# Altium schematic sheet IndicatorBox.SchDoc
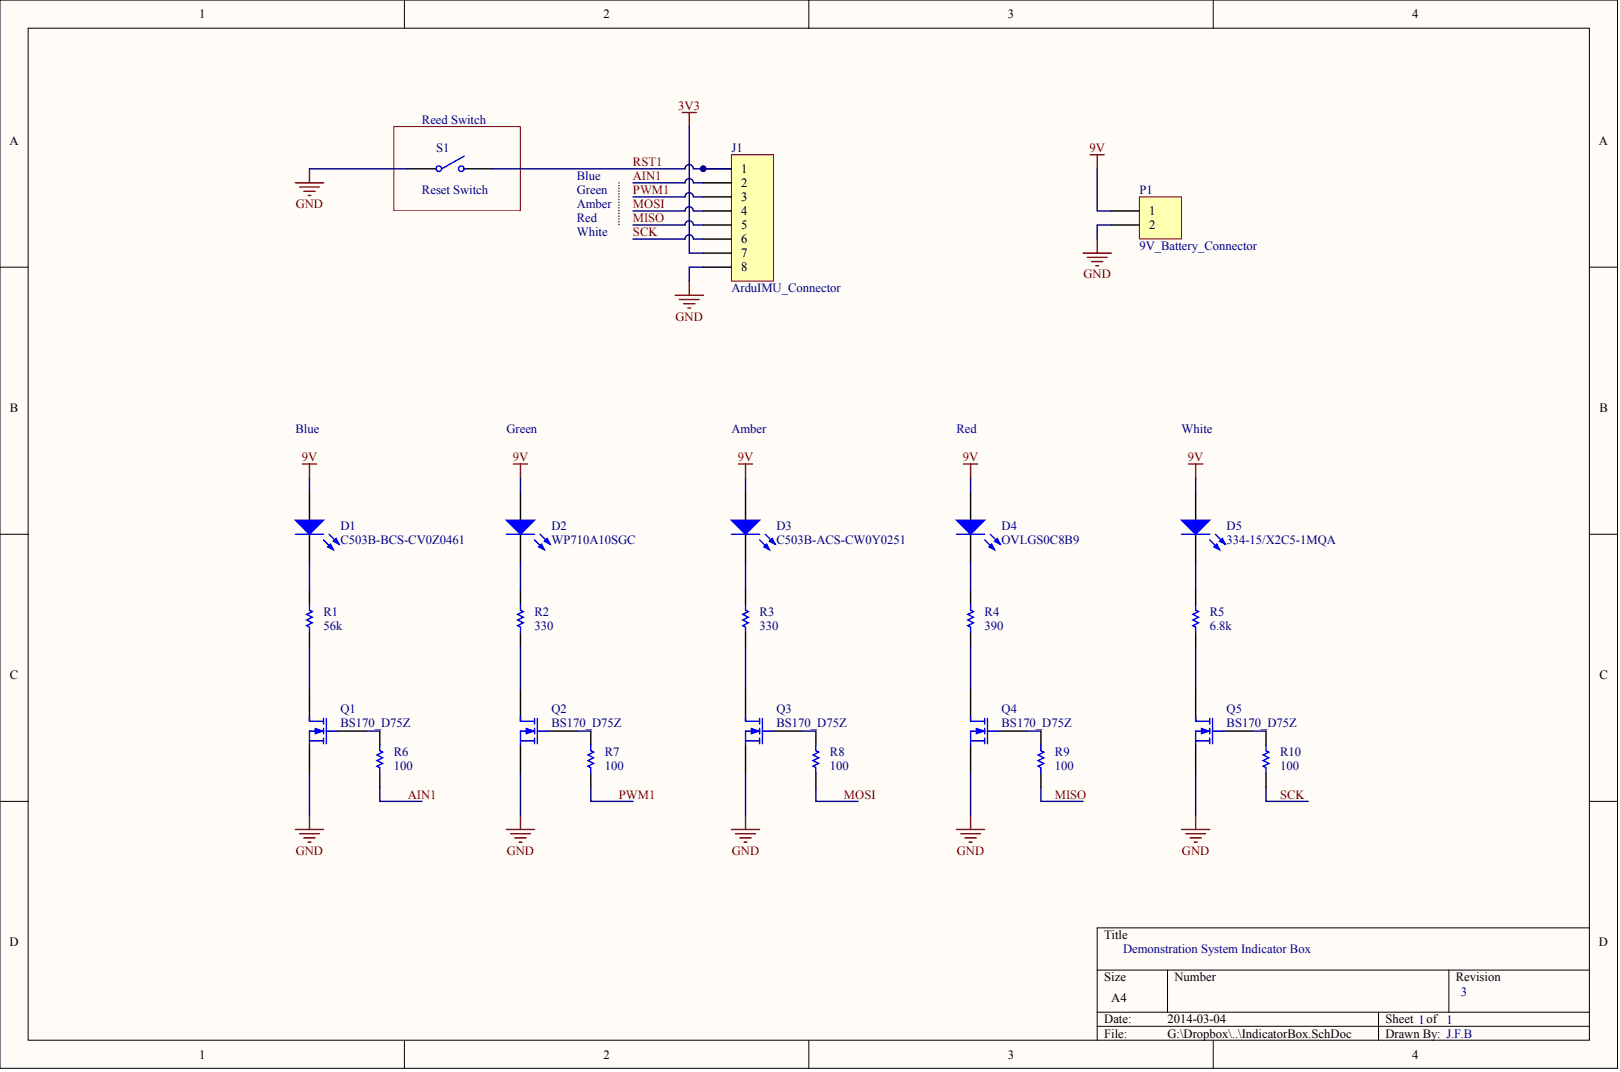
[diagram p0: IndicatorBox.SchDoc]

## Parts
D1  C503B-BCS-CV0Z0461
D2  WP710A10SGC
D3  C503B-ACS-CW0Y0251
D4  OVLGS0C8B9
D5  334-15/X2C5-1MQA
J1  ArduIMU_Connector
P1  9V_Battery_Connector
Q1  BS170_D75Z
Q2  BS170_D75Z
Q3  BS170_D75Z
Q4  BS170_D75Z
Q5  BS170_D75Z
R1  value 56k
R2  value 330
R3  value 330
R4  value 390
R5  value 6.8k
R6  value 100
R7  value 100
R8  value 100
R9  value 100
R10  value 100
S1  Reset Switch

## Nets
3V3: J1.7
9V: D1.1 D2.1 D3.1 D4.1 D5.1 P1.1
GND: J1.8 P1.2 Q1.3 Q2.3 Q3.3 Q4.3 Q5.3 S1.1
AIN1: J1.2 R6.1
MISO: J1.5 R9.1
MOSI: J1.4 R8.1
PWM1: J1.3 R7.1
RST1: J1.1 S1.2
SCK: J1.6 R10.1
NetD1_2: D1.2 R1.2
NetD2_2: D2.2 R2.2
NetD3_2: D3.2 R3.2
NetD4_2: D4.2 R4.2
NetD5_2: D5.2 R5.2
NetQ1_1: Q1.1 R1.1
NetQ1_2: Q1.2 R6.2
NetQ2_1: Q2.1 R2.1
NetQ2_2: Q2.2 R7.2
NetQ3_1: Q3.1 R3.1
NetQ3_2: Q3.2 R8.2
NetQ4_1: Q4.1 R4.1
NetQ4_2: Q4.2 R9.2
NetQ5_1: Q5.1 R5.1
NetQ5_2: Q5.2 R10.2

## Library data of the parts
D1-D5 J1 P1 Q1-Q5 R1-R10 S1 = (no symbol name): Publisher: Altium Limited
  D1-D5: Published: 8-Jun-2000; LatestRevisionDate: 08-Jul-2005; LatestRevisionNote: Mech Layer 1 removed.; PackageReference: LED-0; PackageDescription: LED; 2 Leads
  J1 P1: LatestRevisionDate: 17-Jul-2002; LatestRevisionNote: Re-released for DXP Platform.
  Q1-Q5: Published: 8-Jun-2000; LatestRevisionDate: 17-Jul-2002; LatestRevisionNote: Re-released for DXP Platform.; PackageVersion: 1995/1996; PackageReference: E3; PackageDescription: TO, Flat Index; 3 In-Line, Axial Leads; Body Dia. 4.6mm; Lead Dia. 0.5 mm (max)
  R1-R10: Published: 8-Jun-2000; LatestRevisionDate: 17-Jul-2002; LatestRevisionNote: Re-released for DXP Platform.; PackageReference: AXIAL-0.3; PackageDescription: Axial Device, Thru-Hole; 2 Leads; 0.3 in Pin Spacing
  S1: Published: 24-Mar-1999; LatestRevisionDate: 17-Jul-2002; LatestRevisionNote: Re-released for DXP Platform.; PackageReference: SPST-2; PackageDescription: Switch; 2 Leads
